# Altium schematic sheet USB-PHY.SchDoc(USB-PHY)
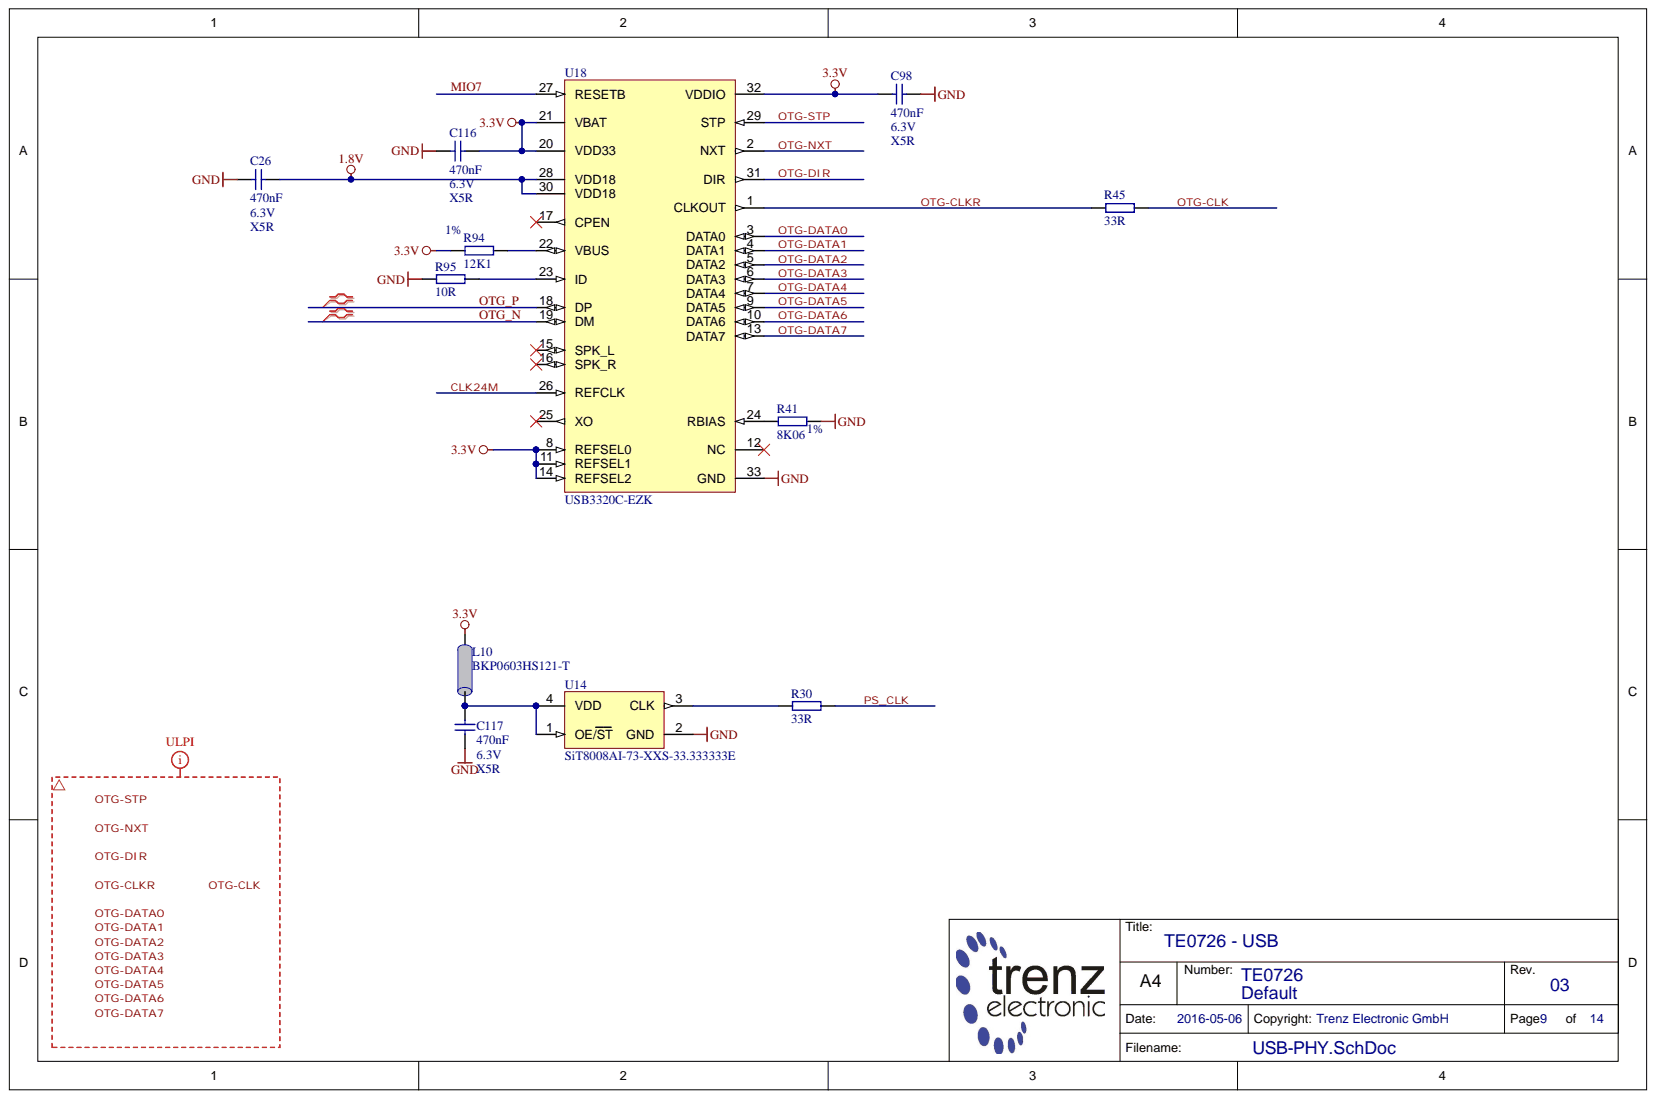
[diagram p0: USB-PHY.SchDoc]

## Parts
C26  470nF  Voltage: 6.3V  [CAPC0603X33N]
C98  470nF  Voltage: 6.3V  [CAPC0603X33N]
C116  470nF  Voltage: 6.3V  [CAPC0603X33N]
C117  470nF  Technology: X5R  Voltage: 6.3V  [CAPC0603X33N]
L10  BKP0603HS121-T  [INDC0603X33N]
R30  33R  [RESC0603X26N]
R41  8K06  Tolerance: 1%  [RESC1005X40N]
R45  33R  [RESC0603X26N]
R94  12K1  Tolerance: 1%  [RESC1005X40N]
R95  10R  [RESC1005X40N]
U14  SiT8008AI-73-XXS-33.333333E  Database Table Name: CLK  [2016]
U18  USB3320C-EZK  [QFN50P500X500X100-33N-345]

## Nets
1.8V: C26.1 U18.28(VDD18) U18.30(VDD18)
3.3V: C98.2 C116.1 L10.1 R94.1 U18.8(REFSEL0) U18.11(REFSEL1) U18.14(REFSEL2) U18.20(VDD33) U18.21(VBAT) U18.32(VDDIO)
GND: C26.2 C98.1 C116.2 C117.2 R41.2 R95.1 U14.2(GND) U18.33(GND)
CLK24M: U18.26(REFCLK)
MIO7: U18.27(RESETB)
OTG-CLK: R45.2
OTG-CLKR: R45.1 U18.1(CLKOUT)
OTG-DATA0: U18.3(DATA0)
OTG-DATA1: U18.4(DATA1)
OTG-DATA2: U18.5(DATA2)
OTG-DATA3: U18.6(DATA3)
OTG-DATA4: U18.7(DATA4)
OTG-DATA5: U18.9(DATA5)
OTG-DATA6: U18.10(DATA6)
OTG-DATA7: U18.13(DATA7)
OTG-DIR: U18.31(DIR)
OTG-NXT: U18.2(NXT)
OTG-STP: U18.29(STP)
OTG_N: U18.19(DM)
OTG_P: U18.18(DP)
PS_CLK: R30.2
NetC117_1: C117.1 L10.2 U14.1(OE/ST) U14.4(VDD)
NetR30_1: R30.1 U14.3(CLK)
NetR41_1: R41.1 U18.24(RBIAS)
NetR94_2: R94.2 U18.22(VBUS)
NetR95_2: R95.2 U18.23(ID)
N00612:

Not connected: U18 12(NC) 15(SPK_L) 16(SPK_R) 17(CPEN) 25(XO)

## Library data of the parts
C26 C98 C116 C117 = 24921: KON 470nF X5R 6.3V SMD 20%; Database Table Name: C_0603_0201; Mixed: SMT; Preferred: Yes; Value: 470n; AN: 24921; Case: 0603 (0201); Component Kind: Standard; Library Name: TE.DbLib; Tolerance: 20%
  C26 C98 C116: Technology: X5R
L10 = 25393: Ferritdurchführung SMD 0201; Database Table Name: L_0603_0201; Mixed: SMT; Preferred: Yes; Tolerance: 25%; AN: 25393; Case: 0603 (0201); Component Kind: Standard; H-ART: BKP0603HS121-T; Library Name: TE.DbLib; Trenz-Stock: Yes; HelpURL: B:\AD\adlib\datasheet\MULTILAYER CHIP BEAD INDUCTORS BK
R30 R45 = 25021: WID SMD 33R 1% 0,05W; Database Table Name: R_0603_0201; Mixed: SMT; Preferred: Yes; Value: 33; AN: 25021; Case: 0603 (0201); Component Kind: Standard; Library Name: TE.DbLib; Technology: TK100; Tolerance: 1%; HelpURL: B:\AD\adlib\datasheet\R0201
R41 = 25052: WID SMD 8K06 1% 0,063W; Database Table Name: R_1005_0402; Mixed: SMT; Preferred: Yes; Value: 8.06K; AN: 25052; Case: 1005 (0402); Component Kind: Standard; Library Name: TE.DbLib; Technology: TK100
R94 = 22849: WID SMD 12K1 1% 0,063W; Database Table Name: R_1005_0402; Mixed: SMT; Preferred: Yes; Value: 12.1K; AN: 22849; Case: 1005 (0402); Component Kind: Standard; Library Name: TE.DbLib; Technology: TK100
R95 = 22844: WID SMD 10R 1% 0,063W; Database Table Name: R_1005_0402; Mixed: SMT; Preferred: Yes; Value: 10; AN: 22844; Case: 1005 (0402); Component Kind: Standard; Library Name: TE.DbLib; Technology: TK100; Tolerance: 1%
U14 = 25023: SiT8008AI-73-XXS-33.333333E MEMS Oszillator 33.333333MHz; Mixed: SMT; Preferred: Yes; Value: 33M; AN: 25023; Case: 2.0  x 1.6mm; Component Kind: Standard; H-ART: SiT8008AI-73-XXS-33.333333E; Library Name: TE.DbLib; Trenz-Stock: Yes; HelpURL: B:\AD\adlib\datasheet\SiT8008
U18 = 24992: Database Table Name: IF; Mixed: SMT; Trenz-Stock: Yes; AN: 24992; Case: QFN32; Component Kind: Standard; Description: USB3320C-EZK; H-ART: USB3320C-EZK; Library Name: TE.DbLib; HelpURL: B:\AD\adlib\datasheet\USB3320
